# Altium schematic sheet [7]DAC.SchDoc
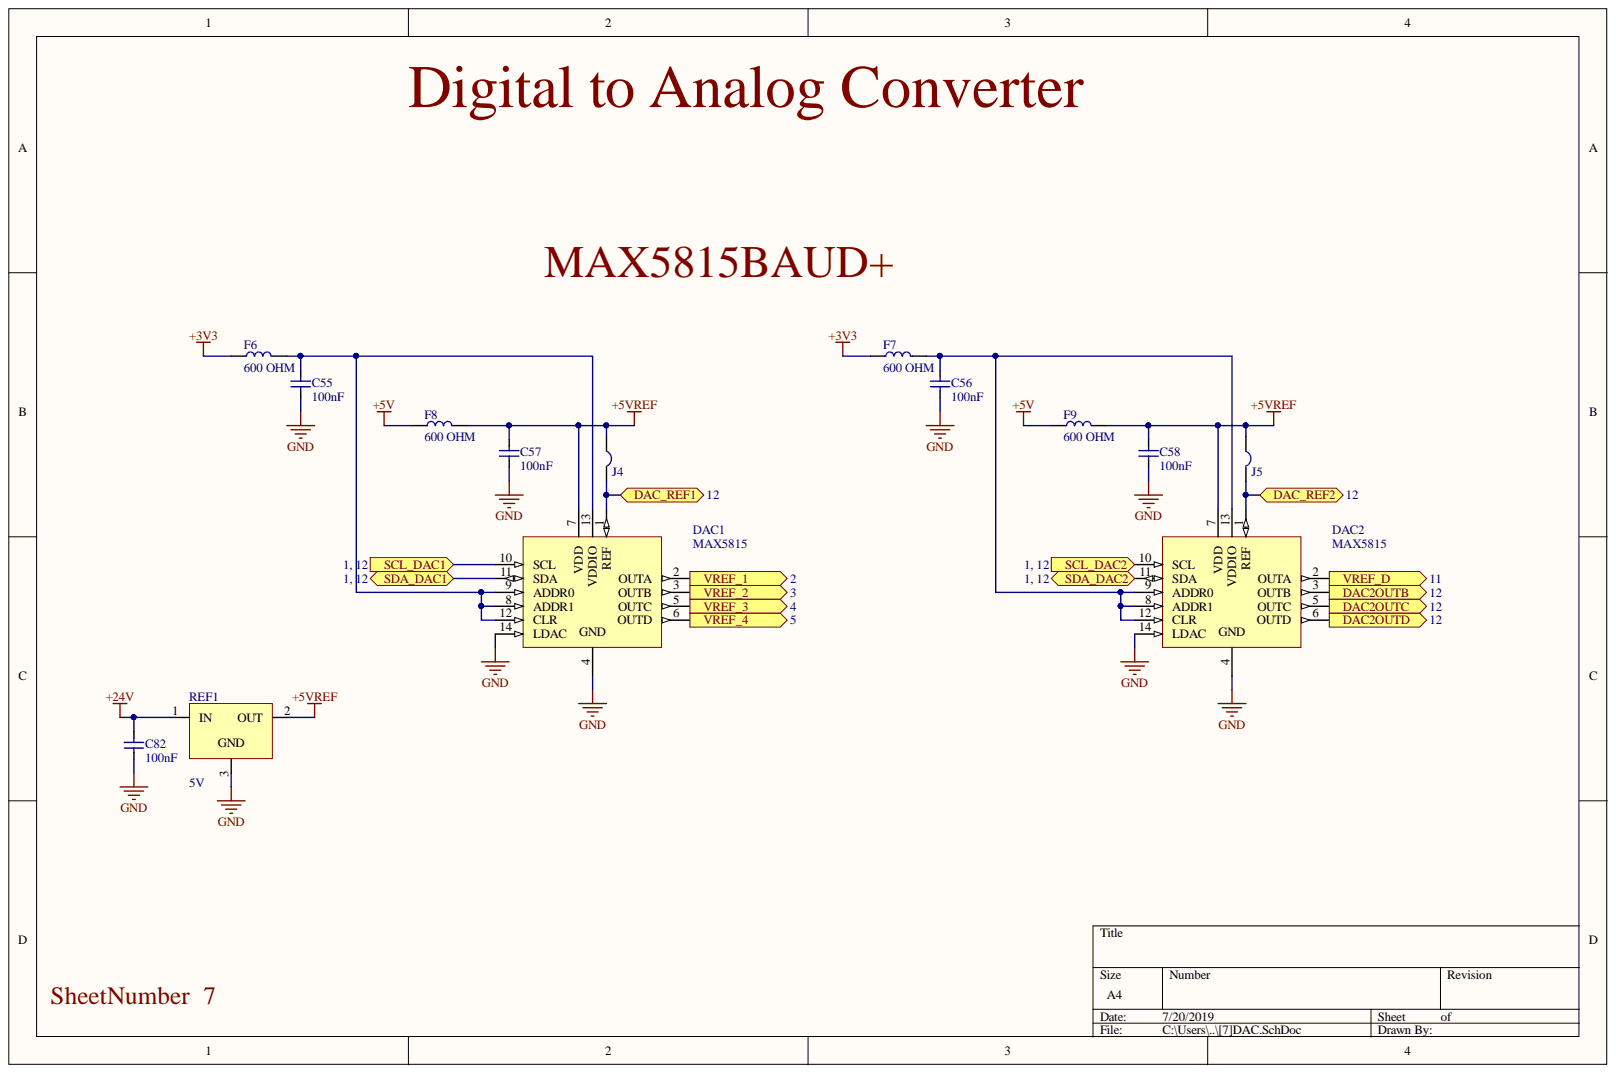
[diagram p0: [7]DAC.SchDoc]

## Parts
C55  100nF  [C0805]
C56  100nF  [C0805]
C57  100nF  [C0805]
C58  100nF  [C0805]
C82  100nF  [C0805]
DAC1  MAX5815  [14 TSSOP]
DAC2  MAX5815  [14 TSSOP]
F6  600 OHM  [FB0805]
F7  600 OHM  [FB0805]
F8  600 OHM  [FB0805]
F9  600 OHM  [FB0805]
J4  Jumper  [Jumper]  (value not drawn)
J5  Jumper  [Jumper]  (value not drawn)
REF1  5V  [SOT23-3]

## Ports
DAC2OUTB, DAC2OUTC, DAC2OUTD, DAC_REF1, DAC_REF2, SCL_DAC1, SCL_DAC2, SDA_DAC1, SDA_DAC2, VREF_1, VREF_2, VREF_3, VREF_4, VREF_D

## Nets
+3V3: F6.1 F7.1
+5V: F8.1 F9.1
+5VREF: C57.1 C58.1 DAC1.7(VDD) DAC2.7(VDD) F8.2 F9.2 J4.1 J5.1 REF1.2
+24V: C82.2 REF1.1
GND: C55.1 C56.1 C57.2 C58.2 C82.1 DAC1.4 DAC1.14(LDAC) DAC2.4 DAC2.14(LDAC) REF1.3
DAC2OUTB: DAC2.3(OUTB) ; port DAC2OUTB
DAC2OUTC: DAC2.5(OUTC) ; port DAC2OUTC
DAC2OUTD: DAC2.6(OUTD) ; port DAC2OUTD
DAC_REF1: DAC1.1(REF) J4.2 ; port DAC_REF1
DAC_REF2: DAC2.1(REF) J5.2 ; port DAC_REF2
SCL_DAC1: DAC1.10(SCL) ; port SCL_DAC1
SCL_DAC2: DAC2.10(SCL) ; port SCL_DAC2
SDA_DAC1: DAC1.11(SDA) ; port SDA_DAC1
SDA_DAC2: DAC2.11(SDA) ; port SDA_DAC2
VREF_1: DAC1.2(OUTA) ; port VREF_1
VREF_2: DAC1.3(OUTB) ; port VREF_2
VREF_3: DAC1.5(OUTC) ; port VREF_3
VREF_4: DAC1.6(OUTD) ; port VREF_4
VREF_D: DAC2.2(OUTA) ; port VREF_D
NetC55_2: C55.2 DAC1.8(ADDR1) DAC1.9(ADDR0) DAC1.12(CLR) DAC1.13(VDDIO) F6.2
NetC56_2: C56.2 DAC2.8(ADDR1) DAC2.9(ADDR0) DAC2.12(CLR) DAC2.13(VDDIO) F7.2

## Library data of the parts
C55-C58 C82 = CC0805ZRY5V9BB104: CAP CER 0.1UF 50V Y5V 0805; Mfr 1: Yageo; Component Kind: Standard; Library Name: Components.SchLib; Mfr 1 PN: CC0805ZRY5V9BB104
DAC1 DAC2 = MAX5815BAUD+: IC DAC 12BIT SRL 4CH 14TSSOP; Mfr 1: Maxim Integrated; Component Kind: Standard; Library Name: Components.SchLib; Mfr 1 PN: MAX5815BAUD+
F6-F9 = MH2029-601Y: FERRITE BEAD 600 OHM 0805 1LN; Mfr 1: Bourns Inc.; Component Kind: Standard; Library Name: Components.SchLib; Mfr 1 PN: MH2029-601Y
REF1 = MAX6035BAUR50+T: IC VREF SERIES 5V SOT23-3; Mfr 1: Maxim Integrated; Component Kind: Standard; Library Name: Components.SchLib; Mfr 1 PN: MAX6035BAUR50+T
J4 J5 = Jumper: Component Kind: Standard; Library Name: Components.SchLib
